# Altium schematic sheet User Interface.SchDoc("User Interface")
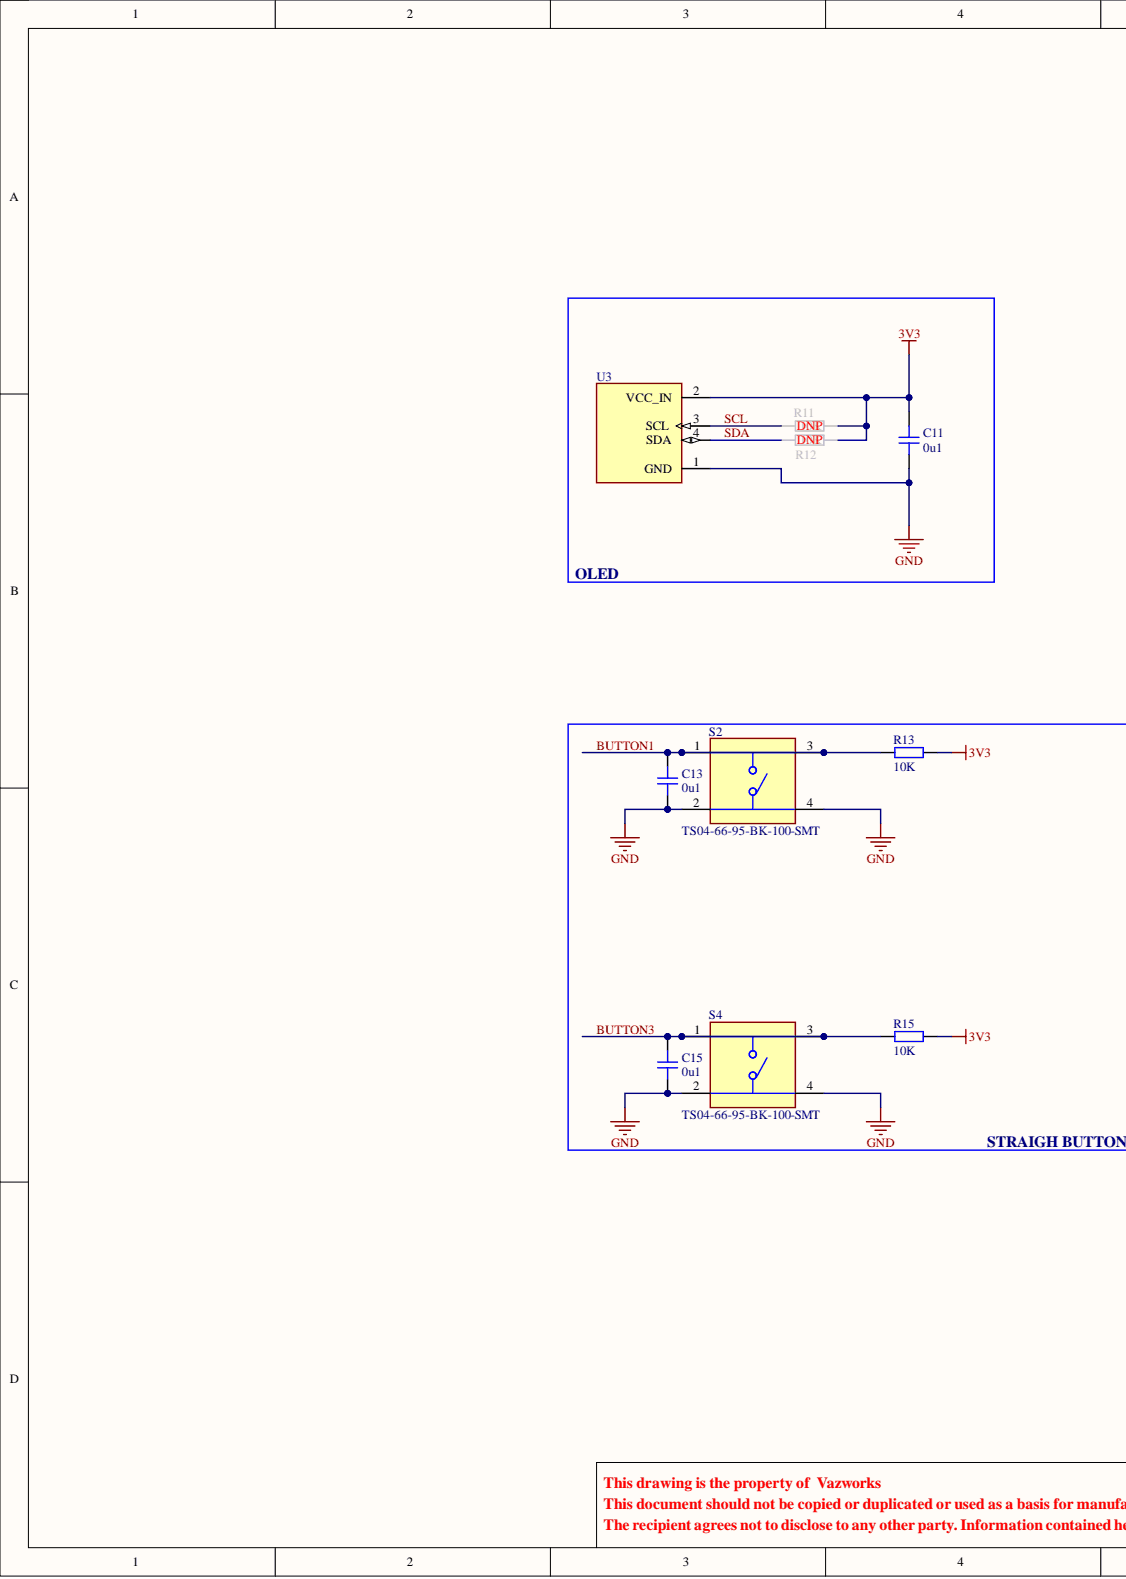
[diagram p0: User Interface.SchDoc, left]
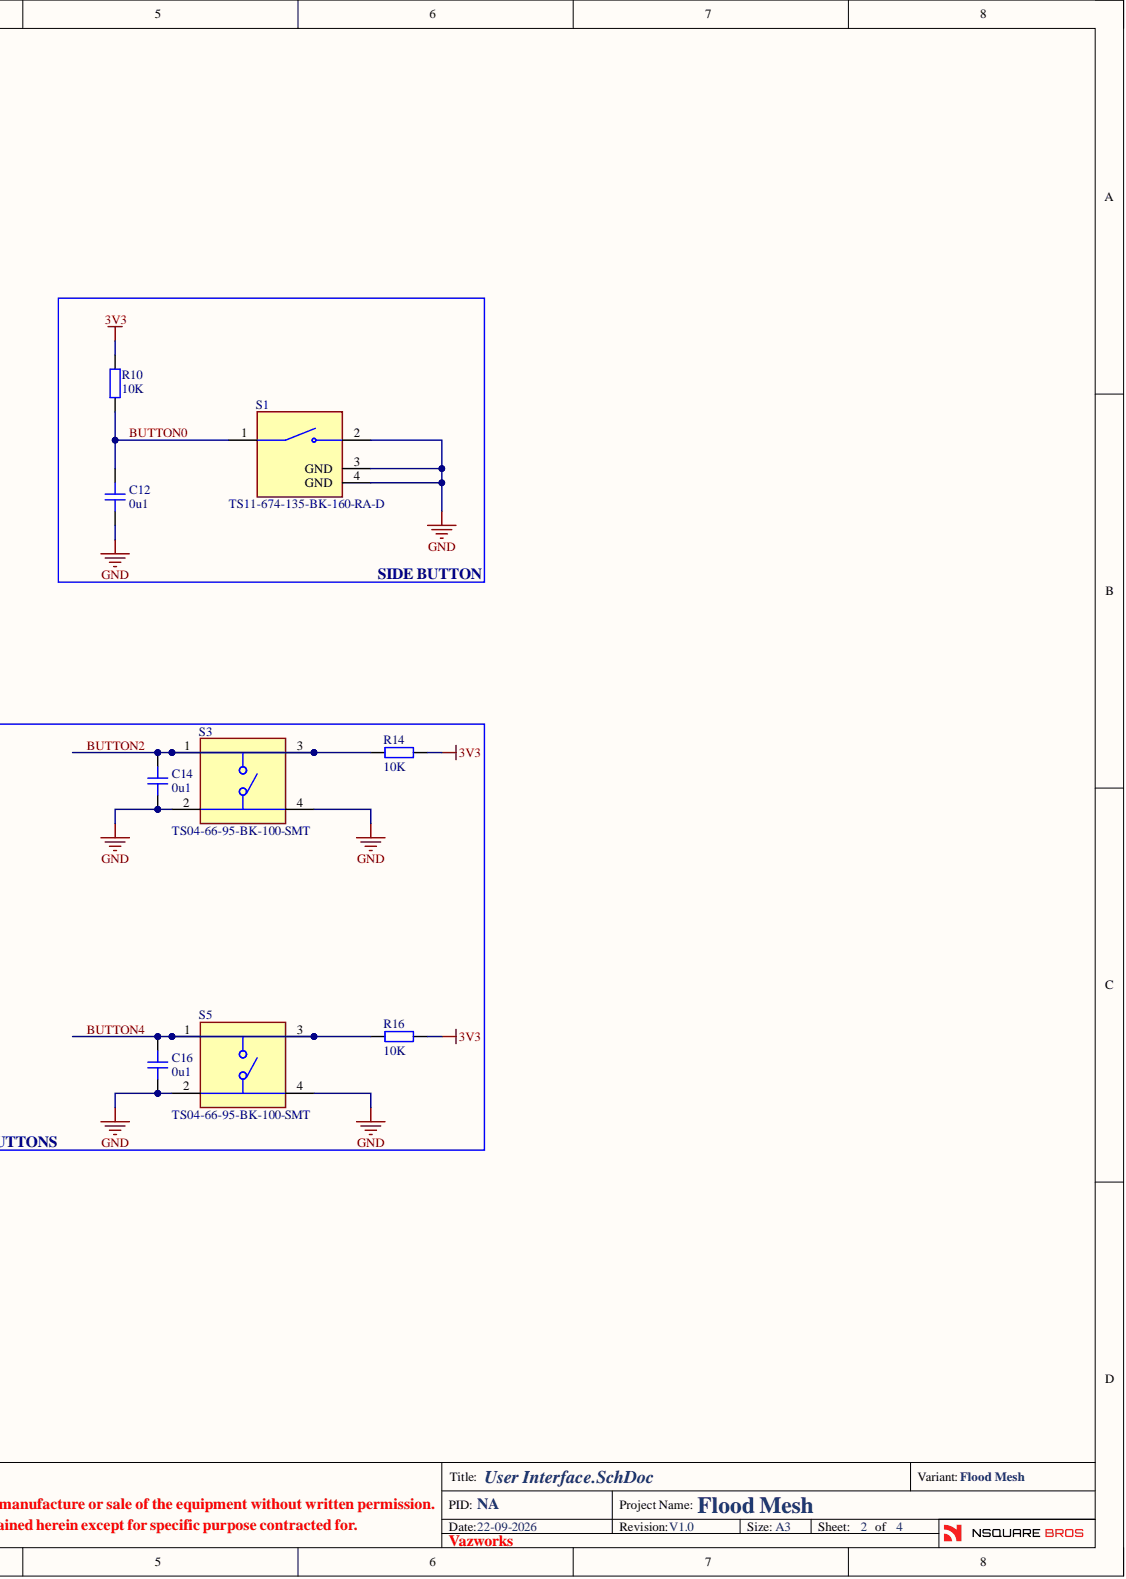
[diagram p1: User Interface.SchDoc, right]

## Parts
C11  value 0u1  [CAP_0402]  (hidden comment: CC0402JRX7R8BB104)
C12  value 0u1  [CAP_0402]  (hidden comment: CC0402JRX7R8BB104)
C13  value 0u1  [CAP_0402]  (hidden comment: CC0402JRX7R8BB104)
C14  value 0u1  [CAP_0402]  (hidden comment: CC0402JRX7R8BB104)
C15  value 0u1  [CAP_0402]  (hidden comment: CC0402JRX7R8BB104)
C16  value 0u1  [CAP_0402]  (hidden comment: CC0402JRX7R8BB104)
R10  value 10K  [RES_0402]  (hidden comment: AC0402FR-7W10KL)
R11  value 10K  [RES_0402]  (hidden comment: AC0402FR-7W10KL)
R12  value 10K  [RES_0402]  (hidden comment: AC0402FR-7W10KL)
R13  value 10K  [RES_0402]  (hidden comment: AC0402FR-7W10KL)
R14  value 10K  [RES_0402]  (hidden comment: AC0402FR-7W10KL)
R15  value 10K  [RES_0402]  (hidden comment: AC0402FR-7W10KL)
R16  value 10K  [RES_0402]  (hidden comment: AC0402FR-7W10KL)
S1  TS11-674-135-BK-160-RA-D  [SW_TS11-674-135-BK-160-RA-D]
S2  TS04-66-95-BK-100-SMT  [SW_TS04-66-95-BK-100-SMT]
S3  TS04-66-95-BK-100-SMT  [SW_TS04-66-95-BK-100-SMT]
S4  TS04-66-95-BK-100-SMT  [SW_TS04-66-95-BK-100-SMT]
S5  TS04-66-95-BK-100-SMT  [SW_TS04-66-95-BK-100-SMT]
U3  0.96 OLED I2C  [MODULE_DM-OLED096-636]  (value not drawn)

## Nets
3V3: C11.2 R10.2 R11.1 R12.1 R13.2 R14.2 R15.2 R16.2 U3.2(VCC_IN)
GND: C11.1 C12.1 C13.1 C14.1 C15.1 C16.1 S1.2 S1.3(GND) S1.4(GND) S2.2 S2.4 S3.2 S3.4 S4.2 S4.4 S5.2 S5.4 U3.1(GND)
BUTTON0: C12.2 R10.1 S1.1
BUTTON1: C13.2 R13.1 S2.1 S2.3
BUTTON2: C14.2 R14.1 S3.1 S3.3
BUTTON3: C15.2 R15.1 S4.1 S4.3
BUTTON4: C16.2 R16.1 S5.1 S5.3
SCL: R11.2 U3.3(SCL)
SDA: R12.2 U3.4(SDA)

## Library data of the parts
C11-C16 = CAP_0402: 25V 100nF X7R ±5% 0402 Multilayer Ceramic Capacitors MLCC - SMD/SMT ROHS; Case/Package: 0402; Category: Ceramic Capacitors; China RoHS: Compliant; Component Kind: Standard; ComponentLink1Description: https://datasheet.octopart.com:443/CC0402JRX7R8BB104-Yageo-datasheet-177165144.pdf?src-supplier=IHS; ComponentLink1URL: https://datasheet.octopart.com:443/CC0402JRX7R8BB104-Yageo-datasheet-177165144.pdf?src-supplier=IHS; ComponentLink2Description: https://datasheet.octopart.com:443/CC0402JRX7R8BB104-Yageo-datasheet-166511530.pdf?src-supplier=Future+Electronics; ComponentLink2URL: https://datasheet.octopart.com:443/CC0402JRX7R8BB104-Yageo-datasheet-166511530.pdf?src-supplier=Future+Electronics; ComponentLink3Description: https://datasheet.octopart.com:443/CC0402JRX7R8BB104-Yageo-datasheet-137700082.pdf?src-supplier=Future+Electronics; ComponentLink3URL: https://datasheet.octopart.com:443/CC0402JRX7R8BB104-Yageo-datasheet-137700082.pdf?src-supplier=Future+Electronics; ComponentLink4Description: https://datasheet.octopart.com:443/CC0402JRX7R8BB104-Yageo-Group-datasheet-165992755.pdf?src-supplier=ODG+(Origin+Data+Global); ComponentLink4URL: https://datasheet.octopart.com:443/CC0402JRX7R8BB104-Yageo-Group-datasheet-165992755.pdf?src-supplier=ODG+(Origin+Data+Global); ComponentLink5Description: https://datasheet.octopart.com:443/CC0402JRX7R8BB104-Yageo-datasheet-151948686.pdf?src-supplier=Farnell; ComponentLink5URL: https://datasheet.octopart.com:443/CC0402JRX7R8BB104-Yageo-datasheet-151948686.pdf?src-supplier=Farnell; ComponentLink6Description: https://datasheet.octopart.com/CC0402JRX7R8BB104-Yageo-datasheet-10883670.pdf; ComponentLink6URL: https://datasheet.octopart.com/CC0402JRX7R8BB104-Yageo-datasheet-10883670.pdf; ComponentLink7Description: http://sigma.octopart.com:80/conflict_mineral_statement/Yageo-company-3.pdf?src-supplier=Compliance+Statements; ComponentLink7URL: http://sigma.octopart.com:80/conflict_mineral_statement/Yageo-company-3.pdf?src-supplier=Compliance+Statements; ComponentLink8Description: http://sigma.octopart.com:80/rohs_statement/Yageo-company-2.pdf?src-supplier=Compliance+Statements; ComponentLink8URL: http://sigma.octopart.com:80/rohs_statement/Yageo-company-2.pdf?src-supplier=Compliance+Statements; Country of Origin: Mainland China, Taiwan; Dielectric Material: Ceramic; Export Control Classification Number (ECCN) Code: EAR99; Halogen Free: Halogen Free; Harmonized Tariff Schedule (HTS) Code: 8532.24.00.20; Height: 500µm; Introduction Date: 2013-05-01; Lead Free: Lead Free; Length: 1mm; Lifecycle Status: Production; Max Operating Temperature: 125°C; Min Operating Temperature: -55°C; Mounting Technology: Surface Mount; Number of Terminals: 2; Pricing: 10000=0.0095, 20000=0.0086 (USD); REACH SVHC: Yes; RoHS: Compliant; Stock: 1050000; Supplier: Arrow Electronics; Supplier Part Number: CC0402JRX7R8BB104; Tolerance: 5%; Voltage Rating (DC): 25V; Width: 500µm; Library Name: Schematic-Lib-PhilsLab.SchLib
R10-R16 = RES_0402: Res Thick Film 0402 10K Ohm 1% 0.125W(1/8W) ±100ppm/C Pad SMD Automotive T/R; Power Dissipation: 125mW; Temperature Coefficient: 100ppm/°C; Voltage Rating: 50V; Working Voltage: 75V; Case/Package: 0402; Category: Chip SMD Resistors; China RoHS: Non-Compliant; Component Kind: Standard; ComponentLink1Description: https://datasheet.octopart.com:443/AC0402FR-7W10KL-Yageo-datasheet-182189377.pdf?src-supplier=IHS; ComponentLink1URL: https://datasheet.octopart.com:443/AC0402FR-7W10KL-Yageo-datasheet-182189377.pdf?src-supplier=IHS; ComponentLink2Description: https://datasheet.octopart.com:443/AC0402FR-7W10KL-Yageo-datasheet-83209224.pdf?src-supplier=Upverter; ComponentLink2URL: https://datasheet.octopart.com:443/AC0402FR-7W10KL-Yageo-datasheet-83209224.pdf?src-supplier=Upverter; ComponentLink3Description: https://datasheet.octopart.com:443/AC0402FR-7W10KL-Yageo-datasheet-178509465.pdf?src-supplier=Farnell; ComponentLink3URL: https://datasheet.octopart.com:443/AC0402FR-7W10KL-Yageo-datasheet-178509465.pdf?src-supplier=Farnell; ComponentLink4Description: https://datasheet.octopart.com:443/AC0402FR-7W10KL-Yageo-datasheet-151884373.pdf?src-supplier=Future+Electronics; ComponentLink4URL: https://datasheet.octopart.com:443/AC0402FR-7W10KL-Yageo-datasheet-151884373.pdf?src-supplier=Future+Electronics; ComponentLink5Description: https://datasheet.octopart.com:443/AC0402FR-7W10KL-Yageo-Group-datasheet-189830439.pdf?src-supplier=Future+Electronics; ComponentLink5URL: https://datasheet.octopart.com:443/AC0402FR-7W10KL-Yageo-Group-datasheet-189830439.pdf?src-supplier=Future+Electronics; ComponentLink6Description: https://datasheet.octopart.com:443/AC0402FR-7W10KL-Yageo-datasheet-166720450.pdf?src-supplier=Future+Electronics; ComponentLink6URL: https://datasheet.octopart.com:443/AC0402FR-7W10KL-Yageo-datasheet-166720450.pdf?src-supplier=Future+Electronics; ComponentLink7Description: https://datasheet.octopart.com:443/AC0402FR-7W10KL-Yageo-Group-datasheet-164768995.pdf?src-supplier=ODG+(Origin+Data+Global); ComponentLink7URL: https://datasheet.octopart.com:443/AC0402FR-7W10KL-Yageo-Group-datasheet-164768995.pdf?src-supplier=ODG+(Origin+Data+Global); ComponentLink8Description: https://datasheet.octopart.com:443/AC0402FR-7W10KL-Yageo-Group-datasheet-159083007.pdf?src-supplier=HQonline; ComponentLink8URL: https://datasheet.octopart.com:443/AC0402FR-7W10KL-Yageo-Group-datasheet-159083007.pdf?src-supplier=HQonline; ComponentLink9Description: https://datasheet.octopart.com/AC0402FR-7W10KL-Yageo-datasheet-12512238.pdf; ComponentLink9URL: https://datasheet.octopart.com/AC0402FR-7W10KL-Yageo-datasheet-12512238.pdf; ComponentLink10Description: http://sigma.octopart.com:80/conflict_mineral_statement/Yageo-company-3.pdf?src-supplier=Compliance+Statements; ComponentLink10URL: http://sigma.octopart.com:80/conflict_mineral_statement/Yageo-company-3.pdf?src-supplier=Compliance+Statements; ComponentLink11Description: http://sigma.octopart.com:80/rohs_statement/Yageo-company-2.pdf?src-supplier=Compliance+Statements; ComponentLink11URL: http://sigma.octopart.com:80/rohs_statement/Yageo-company-2.pdf?src-supplier=Compliance+Statements; Composition: Thick Film; Height: 320µm; Lifecycle Status: Production; Max Operating Temperature: 155°C; Min Operating Temperature: -55°C; Mounting Technology: Surface Mount; Number of Terminals: 2; Packaging: Tape & Reel; Power Rating: 125mW; Pricing: 10000=0.002, 20000=0.00192, 40000=0.00186, 80000=0.00179, 160000=0.00173 (USD); REACH SVHC: Yes; RoHS: Compliant; Stock: 3430000; Supplier: Avnet; Supplier Part Number: AC0402FR-7W10KL; Tolerance: 1%; Width: 500µm; Library Name: Schematic-Lib-PhilsLab.SchLib
S1 = TS11-674-135-BK-160-RA-D: MF: {'manufacturer': u'Same Sky'}; Availability: Unavailable; Component Kind: Standard; MP: TS11-674-135-BK-160-RA-D; Package: None; Price: None
S2-S5 = TS04-66-95-BK-100-SMT: MF: {'manufacturer': u'Same Sky'}; Availability: Unavailable; Component Kind: Standard; MP: TS04-66-95-BK-100-SMT; Package: None; Price: None
U3 = DM-OLED096-636: 0.96â€ 128 X 64 MONOCHROME GRAPHIC OLED DISPLAY MODULE - I2C; Check_prices: https://www.snapeda.com/parts/DM-OLED096-636/Display+Module/view-part/?ref=eda; Component Kind: Standard; Library Name: DM-OLED096-636.IntLib; MF: Display Module; MP: DM-OLED096-636; Package: Package; SnapEDA_Link: https://www.snapeda.com/parts/DM-OLED096-636/Display+Module/view-part/?ref=snap
